# Altium schematic sheet stm32wl_Power.SchDoc
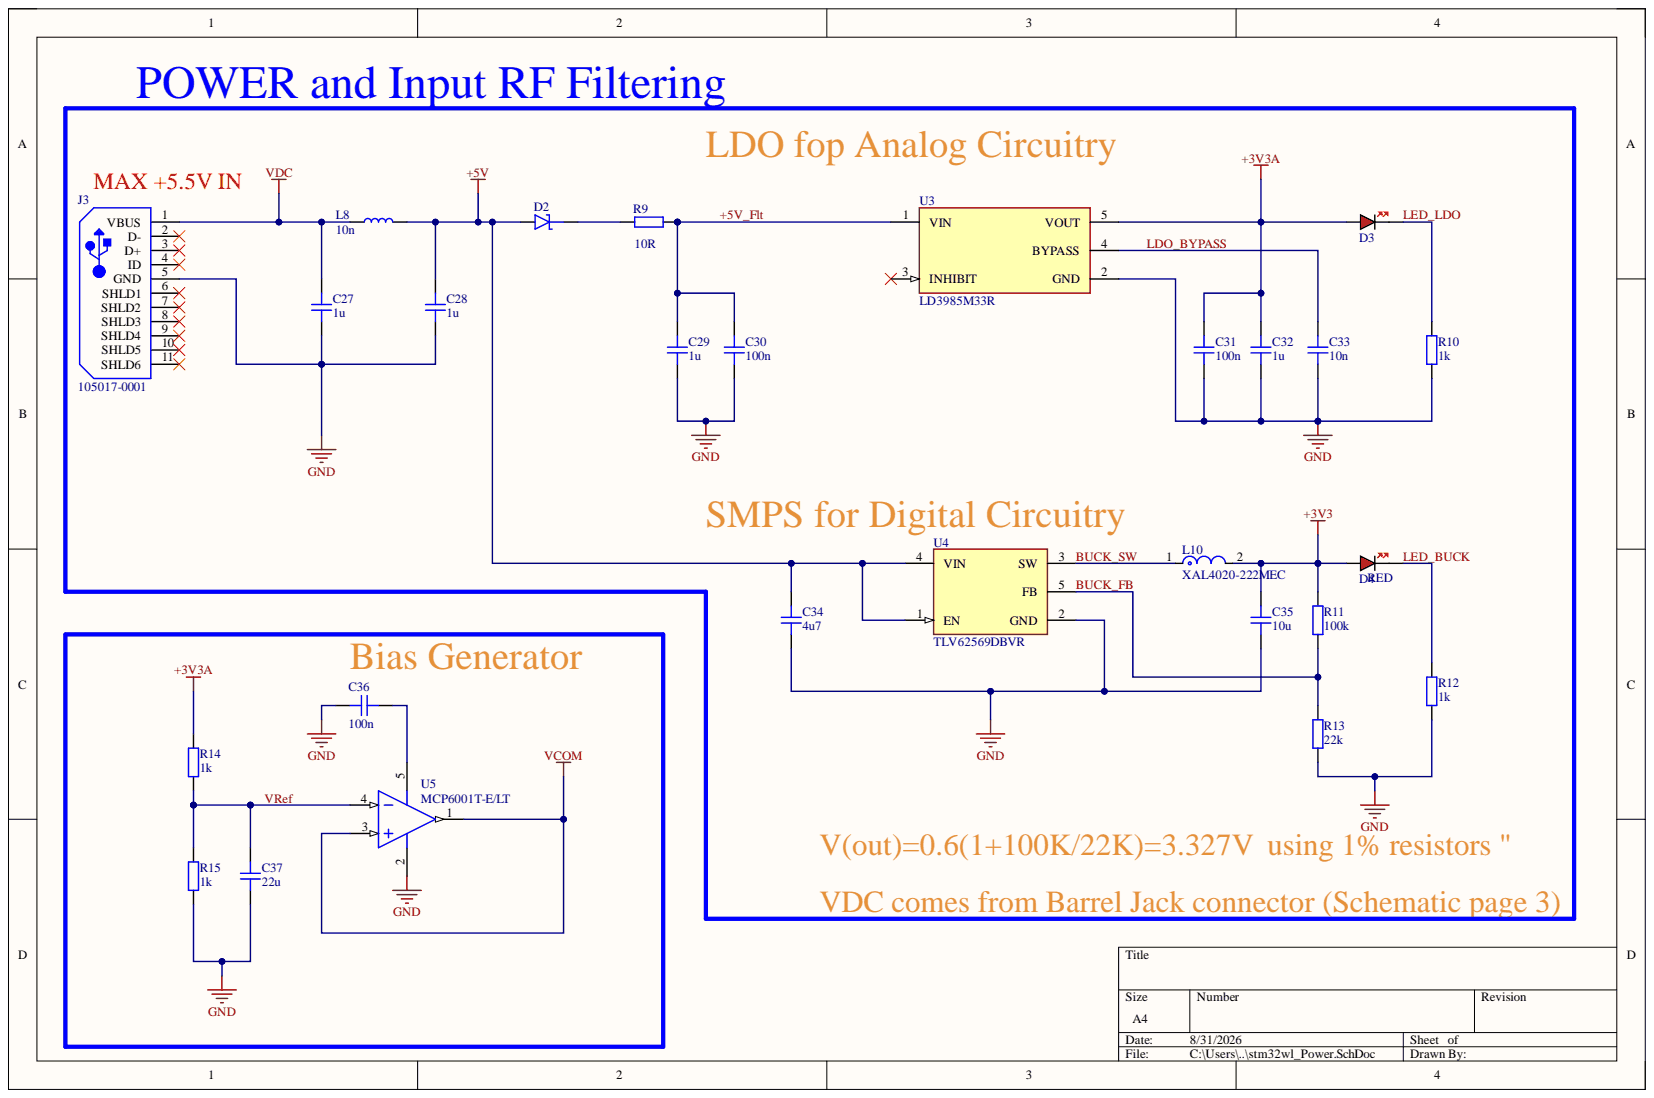
[diagram p0: stm32wl_Power.SchDoc]

## Parts
C27  1u  [CAP_0603]
C28  1u  [CAP_0603]
C29  1u  [CAP_0603]
C30  100n  [CAP_0603]
C31  100n  [CAP_0603]
C32  1u  [CAP_0603]
C33  10n  [CAP_0603]
C34  4u7  [CAP_0603]
C35  10u  [CAP_0603]
C36  100n  [CAP_0402]
C37  22u  [CAP_0603]
D2  D_SCHOTTKY_SOD_323  [SOD-323]  (value not drawn)
D3  RED  [LED_0402_RED]  (value not drawn)
D4  RED  [LED_0402_RED]
J3  105017-0001  [USB-MICRO-B_V]
L8  10n  [IND_0603]
L10  XAL4020-222MEC  [FP-XAL4020-MFG]
R9  10R  [RES_0603]
R10  1k  [RES_0402]
R11  100k  [RES_0402]
R12  1k  [RES_0402]
R13  22k  [RES_0402]
R14  1k  [RES_0402]
R15  1k  [RES_0402]
U3  LD3985M33R  [FP-SOT23-5L-7049676-IPC_C]
U4  TLV62569DBVR  [FP-DBV0005A-MFG]
U5  MCP6001T-E/LT  [SC70-LT5_L]

## Nets
+3V3: C35.2 D4.A L10.2 R11.2
+3V3A: C31.2 C32.2 D3.A R14.2 U3.5(VOUT)
+5V: C28.2 C34.2 D2.A L8.2 U4.1(EN) U4.4(VIN)
GND: C27.1 C28.1 C29.1 C30.1 C31.1 C32.1 C33.1 C34.1 C35.1 C36.2 C37.1 J3.5(GND) R10.1 R12.1 R13.1 R15.1 U3.2(GND) U4.2(GND) U5.2
VCOM: U5.1 U5.3
VDC: C27.2 J3.1(VBUS) L8.1
+5V_Flt: C29.2 C30.2 R9.2 U3.1(VIN)
BUCK_FB: R11.1 R13.2 U4.5(FB)
BUCK_SW: L10.1 U4.3(SW)
LDO_BYPASS: C33.2 U3.4(BYPASS)
LED_BUCK: D4.K R12.2
LED_LDO: D3.K R10.2
VRef: C37.2 R14.1 R15.2 U5.4
NetC36_1: C36.1 U5.5
NetD2_K: D2.K R9.1

Not connected: J3 2(D-) 3(D+) 4(ID) 6(SHLD1) 7(SHLD2) 8(SHLD3) 9(SHLD4) 10(SHLD5) 11(SHLD6); U3 3(INHIBIT)

## Library data of the parts
C27-C35 C37 = CAP_0603_16V: Capacitor SMD 0603 16V; Component Kind: Standard; Library Name: Schematic-Lib-PhilsLab.SchLib
C36 = CAP_0402_16V: Capacitor SMD 0402 16V; Component Kind: Standard; Library Name: Schematic-Lib-PhilsLab.SchLib
D2 = D_SCHOTTKY_SOD_323: Diode Schottky SMD SOD-323; Component Kind: Standard; Library Name: Schematic-Lib-PhilsLab.SchLib
D3 D4 = LED_0402_RED: LED 0402 RED SMD; Component Kind: Standard; Library Name: Schematic-Lib-PhilsLab.SchLib
J3 = CMP-2000-05827-1: Micro-USB B Receptacle, Right Angle, Bottom Mount, Surface Mount, with Solder Tabs, -30 to 85 degC, 5-Pin USB, RoHS, Tape and Reel; Manufacturer: Molex; 3D Model: 105017-0001.stp; Catalog Drawings: 1050170001; Circuits: 5; Connector Type: USB-microB; Featured Product: Micro-USBConnectorsUSBConnectors; Mating Products: WM17145-ND-CABLEMICROUSBBTOSTDA1.0MWM17147-ND-CABLEMICROUSBBTOSTDA2.0MWM17146-ND-CABLEMICROUSBBTOSTDA1.5M; Online Catalog: Molex-MicroUSB; Other Names: WM1399DKR; Package Version: Rev. F, 12/2012; PCN Assembly/Origin: ResinSupplier21/Oct/2015; Voltage - Max: 30V; Category: Connectors,Interconnects; Component Kind: Standard; Datasheet URL: http://www.molex.com/elqNow/elqRedir.htm?ref=http://www.molex.com/webdocs/datasheets/pdf/en-us/1050170001_IO_CONNECTORS.pdf; Datasheet Version: 03/2016; Family: USB,DVI,HDMIConnectors; Features: Shielded,SolderRetention; Gender: Receptacle; Ingress Protection: -; Library Name: Altium Content Vault; Manufacturer URL: http://www.molex.com/; Mounting Technology: SurfaceMount; Mounting Type: BoardCutout,SurfaceMount,RightAngle; Number of Contacts: 5; Package Description: 5-Leads USB, Micro B, Body 7.5 x 5 mm, Pitch 0.65 mm; Package Reference: MICRO-B; Package URL: http://www.molex.com/pdm_docs/sd/1050170001_sd.pdf; Packaging: Digi-Reel®; RoHS Information: 1050170001CertofCompliance; Series: -; Specifications: -; Standard Package: 1
L8 = IND_0603: Inductor SMD 0603; Component Kind: Standard; Library Name: Schematic-Lib-PhilsLab.SchLib
L10 = CMP-11268-000134-1: Fixed Inductors 2.2uH Shld 20% 5.5A 38.7mOhms AECQ2; Core Material: Composite; DC Resistance (DCR): 38.7mR; Saturation Current: 5.6A; Self Resonant Frequency: 52MHz; Shielding: Shielded; Test Frequency: 100kHz; Case/Package: SMD/SMT; Component Kind: Standard; Height: 2.1mm; Inductance: 2.2uH; Inductance Tolerance: 20%; Lead Free: Contains Lead; Length: 4.3mm; Library Name: XAL4020-222ME.SchLib; Max DC Current: 5.5A; Max Operating Temperature: 125°C; Min Operating Temperature: -40°C; Mount: Surface Mount; Package Quantity: 1000; Packaging: Tape and Reel; RMS Current (Irms): 5.5A; RoHS Compliant: Yes; Series: XAL4020; Termination: SMD/SMT; Tolerance: 20%; Width: 4.3mm
R9 = RES_0603: Resistor SMD 0603; Component Kind: Standard; Library Name: Schematic-Lib-PhilsLab.SchLib
R10-R15 = RES_0402: Resistor SMD 0402; Component Kind: Standard; Library Name: Schematic-Lib-PhilsLab.SchLib
U3 = CMP-0244-00328-3: NexarPartId: 526998; Accuracy: 2%; Dropout Voltage: 60mV; Input Bias Current: 85µA; Max Input Voltage: 6V; Max Junction Temperature (Tj): 125°C; Max Output Current: 150mA; Min Current Limit: 300mA; Min Input Voltage: 2.5V; Min Output Voltage: 3.3V; Number of Channels: 1; Output Type: Fixed; Polarity: Positive; Quiescent Current: 150µA; Case/Package: SOT-23; Component Kind: Standard; Height: 1.3mm; Lead Free: Lead Free; Length: 3mm; Library Name: LD3985M33R.SchLib; Lifecycle Status: Production; Max Operating Temperature: 125°C; Max Supply Voltage: 6V; Min Operating Temperature: -40°C; Min Supply Voltage: 2.5V; Mount: Surface Mount; Number of Outputs: 1; Number of Pins: 5; Number of Regulators: 1; Output Current: 150mA; Output Voltage: 3.3V; Output Voltage Accuracy: 2%; Packaging: Cut Tape (CT); Radiation Hardening: No; RoHS: Compliant; Schedule B: 8542390000, 8542390000|8542390000, 8542390000|8542390000|8542390000, 8542390000|8542390000|8542390000|8542390000; Width: 1.75mm
U4 = CMP-04918-000387-1: IC REG BUCK ADJ 2A SOT23-5; Max Input Voltage: 5.5V; Min Input Voltage: 2.5V; Min Output Voltage: 600mV; Output Configuration: Positive; Output Type: Adjustable; Switching Frequency: 1.5MHz; Synchronous Rectifier: Yes; Case/Package: SOT-23-5; Component Kind: Standard; Library Name: Altium Content Vault; Max Operating Temperature: 125°C; Max Output Voltage: 5.5V; Min Operating Temperature: -40°C; Mount: Surface Mount; Output Current: 2A; Packaging: Cut Tape; RoHS Compliant: Yes
U5 = CMP-0187-00463-3: None; Amplifier Type: GENERAL PURPOSE; Bandwidth: 1MHz; Common Mode Rejection Ratio: 60dB; Input Bias Current: 1pA; Input Offset Voltage (Vos): 4.5mV; Max Supply Voltage: 6V; Min Supply Voltage: 1.8V; Nominal Supply Current: 100uA; Number of Amplifiers: 1; Operating Supply Voltage: 5.5V; Output Current: 23mA; Power Supply Rejection Ratio (PSRR): 86dB; Slew Rate: 0.6V/µs; Voltage Gain: 112dB; Case/Package: SC; Component Kind: Standard; Contact Plating: Tin; Gain Bandwidth Product: 1MHz; Height: 1mm; Input Offset Voltage-Max: 4.5mV; Lead Free: Lead Free; Length: 2.25mm; Library Name: Altium Content Vault; Max Operating Temperature: 125°C; Min Operating Temperature: -40°C; Mount: Surface Mount; Number of Channels: 1; Number of Circuits: 1; Number of Elements: 1; Number of Pins: 5; Package Quantity: 3000; Packaging: Tape and Reel; Radiation Hardening: No; REACH SVHC: No SVHC; RoHS Compliant: Yes; Simulation: MCP6001; Slew Rate-Nom: 0.6V/µs; Supply Current: 100uA; Technology: CMOS; Unity Gain Bandwidth Product: 1MHz; Width: 1.35mm
